# Altium schematic sheet DC48V_DC24V_200W_V2.0.SchDoc
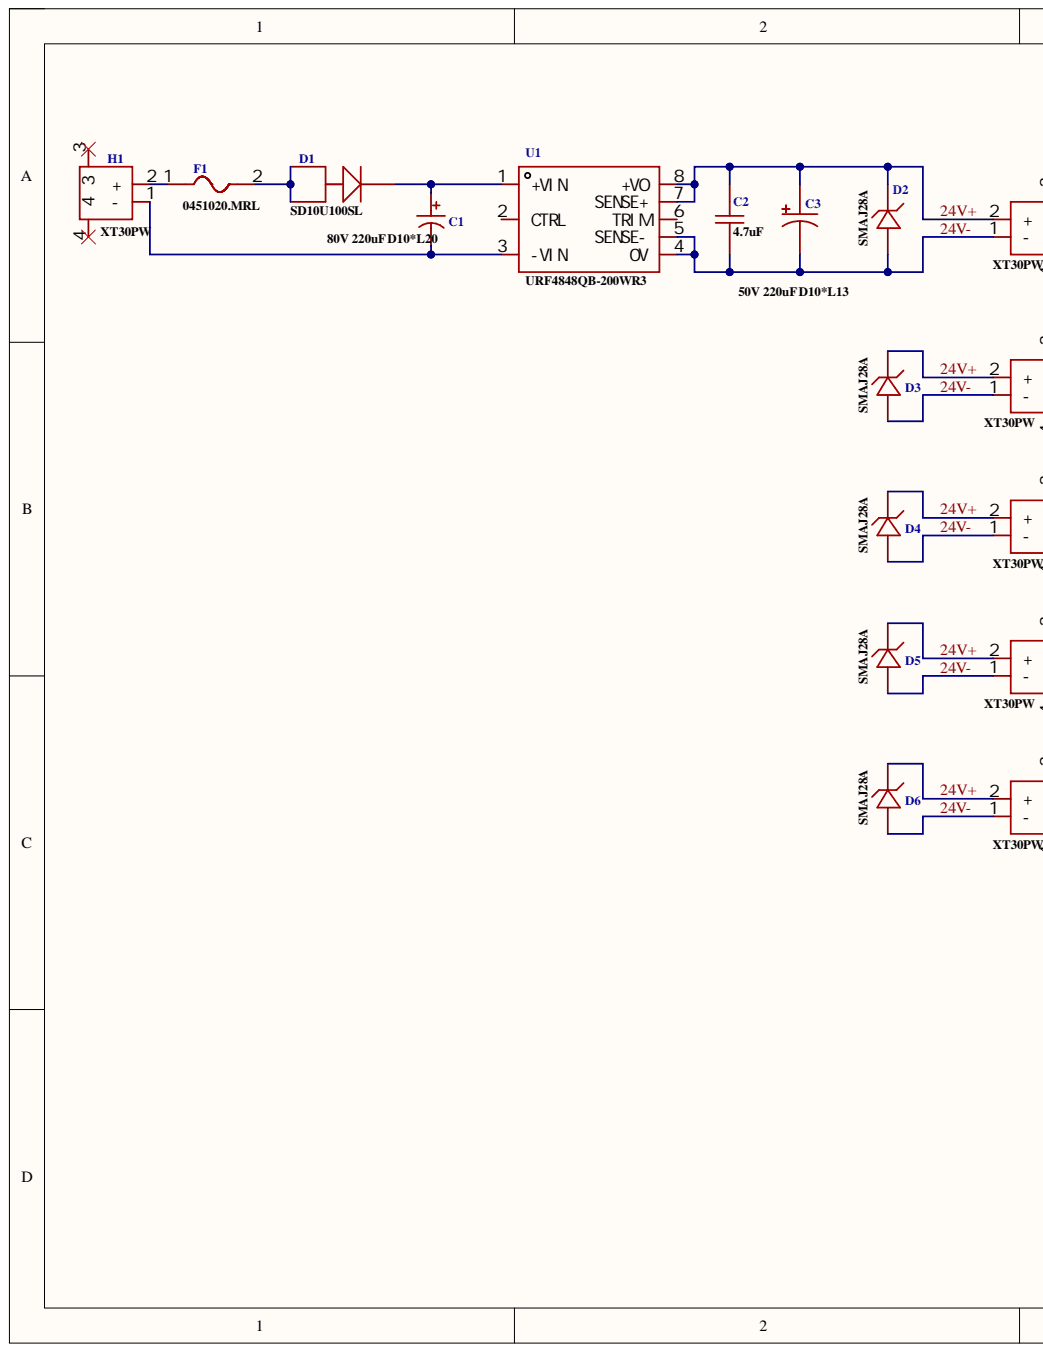
[diagram p0: DC48V_DC24V_200W_V2.0.SchDoc, left]
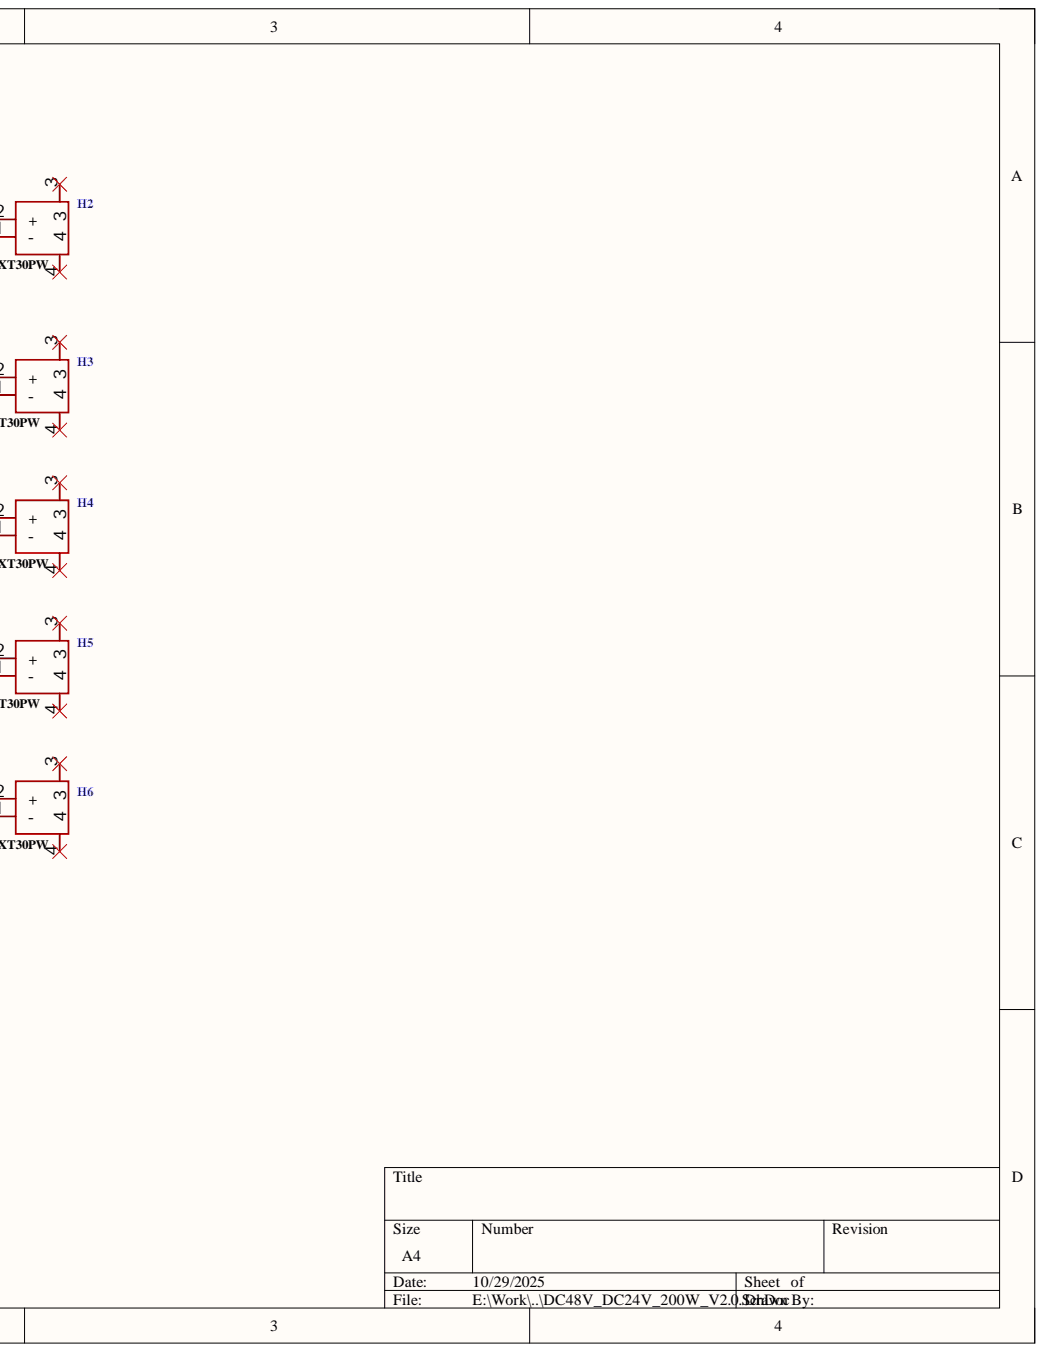
[diagram p1: DC48V_DC24V_200W_V2.0.SchDoc, right]

## Parts
C1  80V 220uF D10*L20  value 220uF  [CAP-TH_BD10.0-P5.00-D1.0-FD-WL]
C2  4.7uF  [1210_C]
C3  50V 220uF D10*L13  value 220uF  [CAP-TH_BD10.0-P5.00-D1.0-FD]
D1  SD10U100SL  [TO-227B-SMD_L6.1-W4.3-LS7.0-RD]
D2  SMAJ28A  [SMA_L4.4-W2.8-LS5.4-RD]
D3  SMAJ28A  [SMA_L4.4-W2.8-LS5.4-RD]
D4  SMAJ28A  [SMA_L4.4-W2.8-LS5.4-RD]
D5  SMAJ28A  [SMA_L4.4-W2.8-LS5.4-RD]
D6  SMAJ28A  [SMA_L4.4-W2.8-LS5.4-RD]
F1  0451020.MRL  [FUSE-SMD_L6.1-W2.7]
H1  XT30PW  [CONN-TH_XT30PW-M]
H2  XT30PW  [CONN-TH_XT30PW-M]
H3  XT30PW  [CONN-TH_XT30PW-M]
H4  XT30PW  [CONN-TH_XT30PW-M]
H5  XT30PW  [CONN-TH_XT30PW-M]
H6  XT30PW  [CONN-TH_XT30PW-M]
U1  URF4848QB-200WR3  [PWRM-TH_URF48XXQB-200WR3]

## Nets
24V+: C2.2 C3.1 D2.1 D3.1 D4.1 D5.1 D6.1 H2.2(+) H3.2(+) H4.2(+) H5.2(+) H6.2(+) U1.7(SENSE+) U1.8(+VO)
24V-: C2.1 C3.2 D2.2 D3.2 D4.2 D5.2 D6.2 H2.1(-) H3.1(-) H4.1(-) H5.1(-) H6.1(-) U1.4(0V) U1.5(SENSE-)
NetC1_1: C1.1 D1.3 U1.1(+VIN)
NetC1_2: C1.2 H1.1(-) U1.3(-VIN)
NetD1_1: D1.1 D1.2 F1.2
NetF1_1: F1.1 H1.2(+)

Not connected: H1 3 4; H2 3 4; H3 3 4; H4 3 4; H5 3 4; H6 3 4; U1 2(CTRL) 6(TRIM)

## Library data of the parts
C1 = ERF1BM221G20OT: Manufacturer: AISHI(艾华集团); Add into BOM: yes; Lifetime: 5000hrs@105℃; Pin Spacing: 5mm; Tolerance: ±20%; Component Kind: Standard; Convert to PCB: yes; Datasheet: https://item.szlcsc.com/datasheet/ERF1BM221G20OT/107732.html; Device: ERF1BM221G20OT; Diameter: 10mm; Equivalent Series Resistance(ESR): -; Height - Seated (Max): 20mm; JLCPCB Part Class: Extended Part; LCSC Part Name: 220uF ±20% 80V; Library Name: 电容.SchLib; Manufacturer Part: ERF1BM221G20OT; Ripple Current: -; Supplier: LCSC; Supplier Footprint: 插件,D10xL20mm; Supplier Part: C106516; Symbol: ERF1BM221G20OT; Voltage Rating: 80V
C2 = 1210 4.7uf: 100V 4.7uf 1210; Manufacturer: FH(风华); Add into BOM: yes; Device: 1210B475K101NT; Temperature Coefficient: X7R; Tolerance: ±10%; Component Kind: Standard; Convert to PCB: yes; Datasheet: https://atta.szlcsc.com/upload/public/pdf/source/20210617/C2840282_5B7AB5BFD77495008CFAB3FC2796A0E9.pdf; JLCPCB Part Class: Extended Part; LCSC Part Name: 4.7uF ±10% 100V; Library Name: Schlib1.SchLib; Manufacturer Part: 1210B475K101NT; Supplier: LCSC; Supplier Footprint: 1210; Supplier Part: C2840282; Symbol: 1210B475K101NT; Value: 4.7uF; Voltage Rated: 100V
C3 = ERS1HM221G13OT: Manufacturer: AISHI(艾华集团); Add into BOM: yes; Lifetime: 7000hrs@105℃; Pin Spacing: 5mm; Tolerance: ±20%; Component Kind: Standard; Convert to PCB: yes; Datasheet: https://item.szlcsc.com/datasheet/ERS1HM221G13OT/356226.html; Device: ERS1HM221G13OT; Diameter: 10mm; Height - Seated (Max): 13mm; JLCPCB Part Class: Extended Part; LCSC Part Name: 220uF ±20% 50V; Library Name: 电容.SchLib; Manufacturer Part: ERS1HM221G13OT; PCB3D: CAP-TH_D10.0-H16.0-P5.00; Supplier: LCSC; Supplier Footprint: 插件,D10xL13mm; Supplier Part: C383039; Symbol: ERS1HM221G13OT; Voltage Rating: 50V
D1 = SD10U100SL: Manufacturer: LGE(鲁光); Add into BOM: yes; Diode Configuration: 独立式; Forward Voltage (Vf@If): 570mV@10A; Rectified Current: 10A; Reverse Leakage Current (Ir): 200uA@100V; Reverse Voltage (Vr): 100V; Component Kind: Standard; Convert to PCB: yes; Datasheet: https://atta.szlcsc.com/upload/public/pdf/source/20211009/BD93C46FB22A14806A56CC8AAFB52095.pdf; Device: SD10U100SL; JLCPCB Part Class: Extended Part; LCSC Part Name: 电压:100V 电流:10A; Manufacturer Part: SD10U100SL; Supplier: LCSC; Supplier Footprint: TO-277; Supplier Part: C2903879; Symbol: SD10U100SL; Library Name: 二极管稳压等.SchLib
D2-D6 = SMAJ28A_C148227: Manufacturer: Littelfuse(美国力特); Add into BOM: yes; Clamping Voltage: 45.4V; level of protection: IEC 61000-4-4；IEC 61000-4-2; Peak Pulse Current (Ipp): 8.8A@10/1000us; Peak Pulse Power Dissipation (Ppp): 400W@10/1000us; Polarity: 单向; Reverse Leakage Current (Ir): 1uA; Reverse Stand-Off Voltage (Vrwm): 28V; Type: TVS; Voltage - Breakdown: 34.4V; Component Kind: Standard; Convert to PCB: yes; Datasheet: https://item.szlcsc.com/datasheet/SMAJ28A/159560.html; Device: SMAJ28A_C148227; JLCPCB Part Class: Extended Part; LCSC Part Name: SMAJ28A; Manufacturer Part: SMAJ28A; Operating Temperature: -65℃~+150℃; PCB3D: SMA_L4.4-W2.8-LS5.4-RD; Supplier: LCSC; Supplier Footprint: SMA(DO-214AC); Supplier Part: C148227; Symbol: SMAJ28A_C148227; Library Name: 二极管稳压等.SchLib
F1 = 0451020.MRL: Manufacturer: Littelfuse(美国力特); Add into BOM: yes; Current Rating: 20A; Interrupt Rating: 150A；100A; Type: 贴片式保险丝; Voltage Rating (AC): 65V; Component Kind: Standard; Convert to PCB: yes; Datasheet: https://item.szlcsc.com/datasheet/0451020.MRL/190373.html; Device: 0451020.MRL; JLCPCB Part Class: Extended Part; LCSC Part Name: 65V贴片式保险丝; Library Name: 保险.SchLib; Manufacturer Part: 0451020.MRL; Operating Temperature: -55℃~+125℃; PCB3D: FUSE-SMD_L6.1-W2.7-H2.7; Supplier: LCSC; Supplier Footprint: 2410; Supplier Part: C178980; Symbol: 0451020.MRL; Voltage Rating (DC): 65V
H1-H6 = XT30PW-M30.G.Y: Manufacturer: AMASS(艾迈斯); Add into BOM: yes; contact resistance: 1.2mΩ; InterfaceType: 公头; RatedCurrent: 15A; RatedVoltage: DC 500V; RecommendedWireGauge: 16/18/20AWG; specifications: 2pin; Belt length: -; Colour: -; Component Kind: Standard; Convert to PCB: yes; Datasheet: https://atta.szlcsc.com/upload/public/pdf/source/20220222/6A9F22F7850E25B33E1BE430ADF8560B.pdf; Device: XT30PW-M30.G.Y; FlameRetardantGrade: -; Input: -; InsulationMaterial: -; Interface: -; JLCPCB Part Class: Extended Part; LCSC Part Name: AMASS(艾迈斯)航模插头 卧式弯脚 XT30PW-M公头; Library Name: Header.SchLib; Manufacturer Part: XT30PW-M30.G.Y; MaximumCurrent: -; MetalMaterial: -; NumberOfUSBHoles: -; Output: -; Power: -; Size: -; Supplier: LCSC; Supplier Footprint: -; Supplier Part: C431092; Symbol: XT30PW-M; Type: 航模插头; Type1: -
U1 = URF4848QB-200WR3: Manufacturer: MORNSUN(金升阳); Add into BOM: yes; Capacitive Load(Max): 450uF; Conversion Efficiency: 91%; Isolation Voltage(Vrms): 2.25kV; Output Current(Max): 4.2A; Output Power: 200W; Output Voltage: 48V; Ripple Noise: 150mVp-p; Value: 250kHz; Voltage - Supply: 18V~75V; Component Kind: Standard; Convert to PCB: yes; Datasheet: https://item.szlcsc.com/datasheet/URF4848QB-200WR3/7661650.html; Device: URF4848QB-200WR3; Features: 欠压保护；短路保护；过流保护；过压保护；过热保护; Height: 12.7mm; JLCPCB Part Class: Extended Part; LCSC Part Name: URF4848QB-200WR3; Length: 61.8mm; Manufacturer Part: URF4848QB-200WR3; Operating Temperature: -40℃~+85℃; PCB3D: PWRM-TH_URF48XXQB-200WR3; Supplier: LCSC; Supplier Footprint: DIP,61.8x40.2mm; Supplier Part: C6705194; Symbol: URF4848QB-200WR3; Width: 40.2mm; Library Name: 电源及控制.SchLib
